# Altium schematic sheet AND operations 2.SchDoc("AND operations 2")
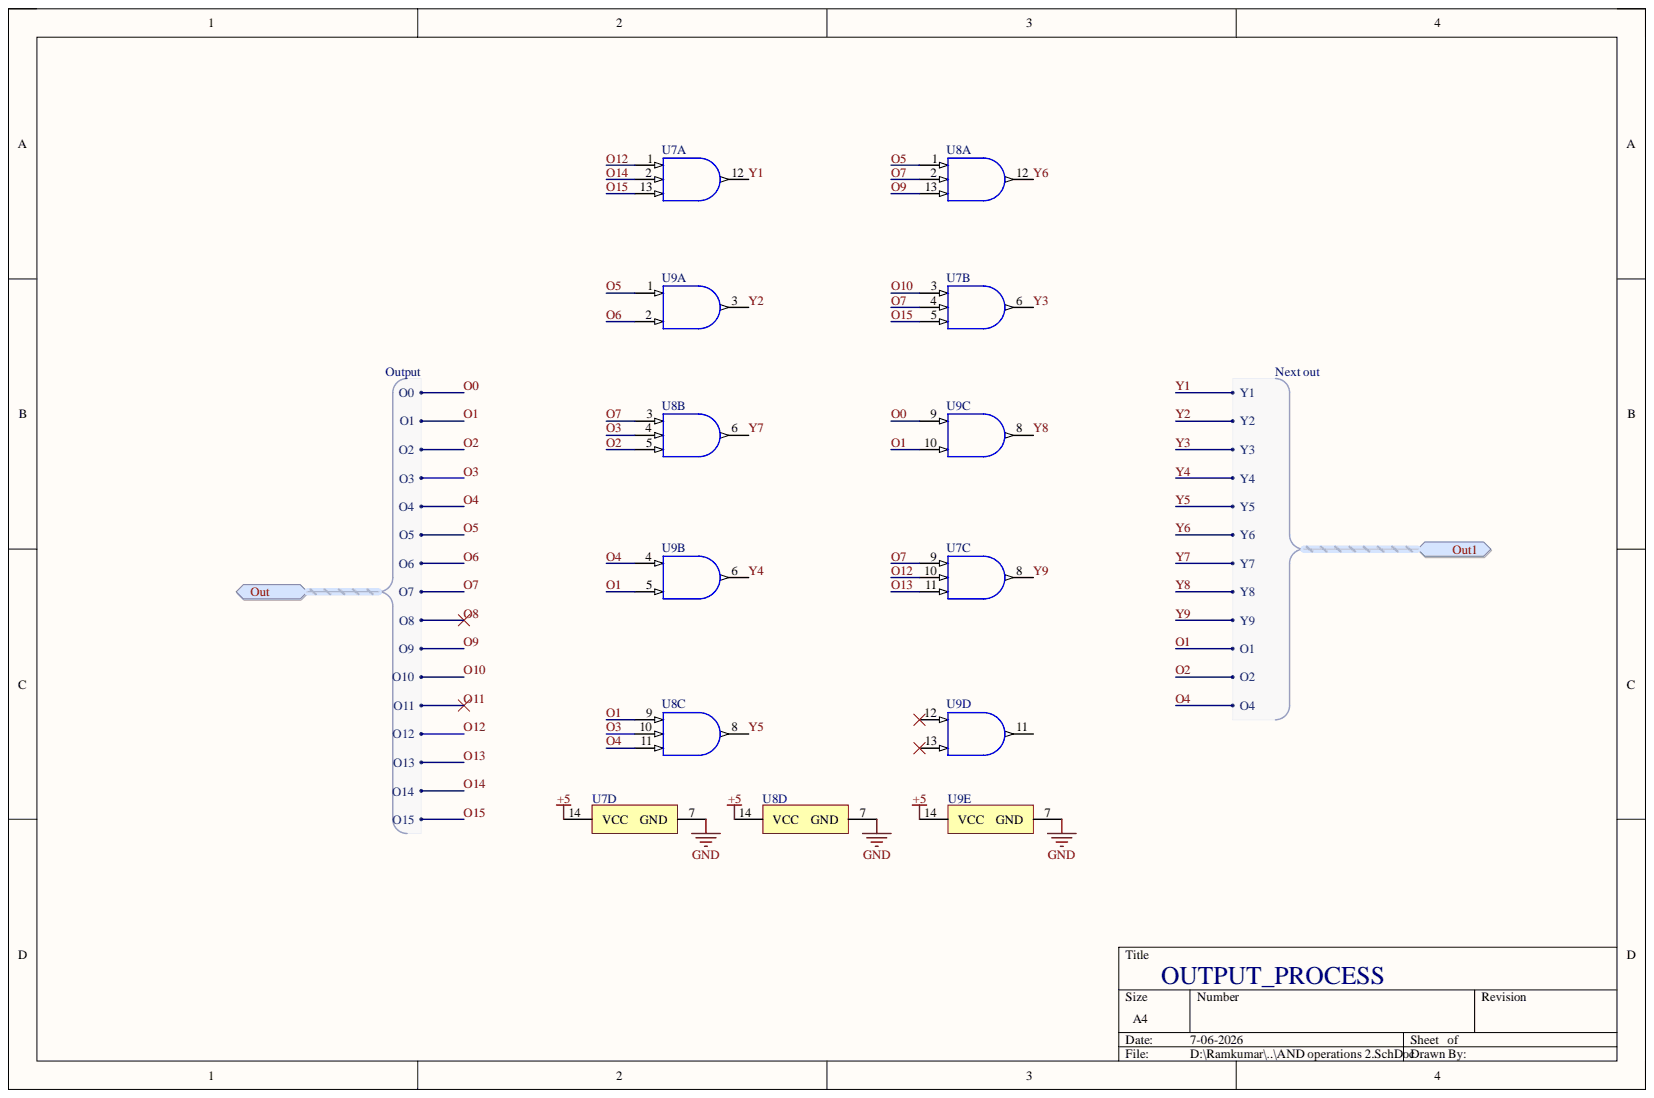
[diagram p0: AND operations 2.SchDoc]

## Parts
U7  SN74LS11N  [N0014A]  (value not drawn)  (parts A,B,C,D)
U8  SN74LS11N  [N0014A]  (value not drawn)  (parts A,B,C,D)
U9  SN74S08N  [N0014A]  (value not drawn)  (parts A,B,C,D,E)

## Ports
Out, Out1, Out1.O1, Out1.O2, Out1.O4, Out1.Y1, Out1.Y2, Out1.Y3, Out1.Y4, Out1.Y5, Out1.Y6, Out1.Y7, Out1.Y8, Out1.Y9, Out.O0, Out.O1, Out.O2, Out.O3, Out.O4, Out.O5, Out.O6, Out.O7, Out.O8, Out.O9, Out.O10, Out.O11, Out.O12, Out.O13, Out.O14, Out.O15

## Nets
+5: U7.14(VCC) U8.14(VCC) U9.14(VCC)
GND: U7.7(GND) U8.7(GND) U9.7(GND)
O0: U9.9 ; port Out
O1: U8.9 U9.5 U9.10 ; port Out, port Out1
O2: U8.5 ; port Out, port Out1
O3: U8.4 U8.10 ; port Out
O4: U8.11 U9.4 ; port Out, port Out1
O5: U8.1 U9.1 ; port Out
O6: U9.2 ; port Out
O7: U7.4 U7.9 U8.2 U8.3 ; port Out
O9: U8.13 ; port Out
O10: U7.3 ; port Out
O12: U7.1 U7.10 ; port Out
O13: U7.11 ; port Out
O14: U7.2 ; port Out
O15: U7.5 U7.13 ; port Out
Y1: U7.12 ; port Out1
Y2: U9.3 ; port Out1
Y3: U7.6 ; port Out1
Y4: U9.6 ; port Out1
Y5: U8.8 ; port Out1
Y6: U8.12 ; port Out1
Y7: U8.6 ; port Out1
Y8: U9.8 ; port Out1
Y9: U7.8 ; port Out1
O8: ; port Out
O11: ; port Out

Not connected: U9 11 12 13

## Library data of the parts
U7 U8 = CMP-0777-00254-3: Triple 3-input positive-AND gates, N0014A, TUBE; Manufacturer: Texas Instruments; Bits(#): 3; F @ Nom Voltage(Max)(Mhz): 35; ICC @ Nom Voltage(Max)(mA): 0.0036; Input Type: TTL; Output Drive (IOL/IOH)(Max)(mA): 0/8; Rating: Catalog; Schmitt Trigger: No; Technology Family: LS; tpd @ Nom Voltage(Max)(ns): 15; VCC(Max)(V): 5.25; VCC(Min)(V): 4.75; Voltage(Nom)(V): 5; Component Kind: Standard; DatasheetVersion: SDLS131; Generic Part Number: SN74LS11; Library Name: Altium Content Vault; Operating Temperature Range(C): 0 to 70; Output Type: TTL; PackageReference: N0014A
U9 = CMP-0777-00282-3: Quad 2-input positive-AND gates, N0014A, TUBE; Manufacturer: Texas Instruments; Bits(#): 4; F @ Nom Voltage(Max)(Mhz): 50; ICC @ Nom Voltage(Max)(mA): 0.032; Input Type: TTL; Output Drive (IOL/IOH)(Max)(mA): -24/24; Rating: Catalog; Schmitt Trigger: No; Technology Family: S; tpd @ Nom Voltage(Max)(ns): 7.5; VCC(Max)(V): 5.25; VCC(Min)(V): 4.75; Voltage(Nom)(V): 5; Component Kind: Standard; DatasheetVersion: SDLS033; Generic Part Number: SN74S08; Library Name: Altium Content Vault; Operating Temperature Range(C): 0 to 70; Output Type: TTL; PackageReference: N0014A
